# Altium schematic sheet TestPad_Schematic.SchDoc
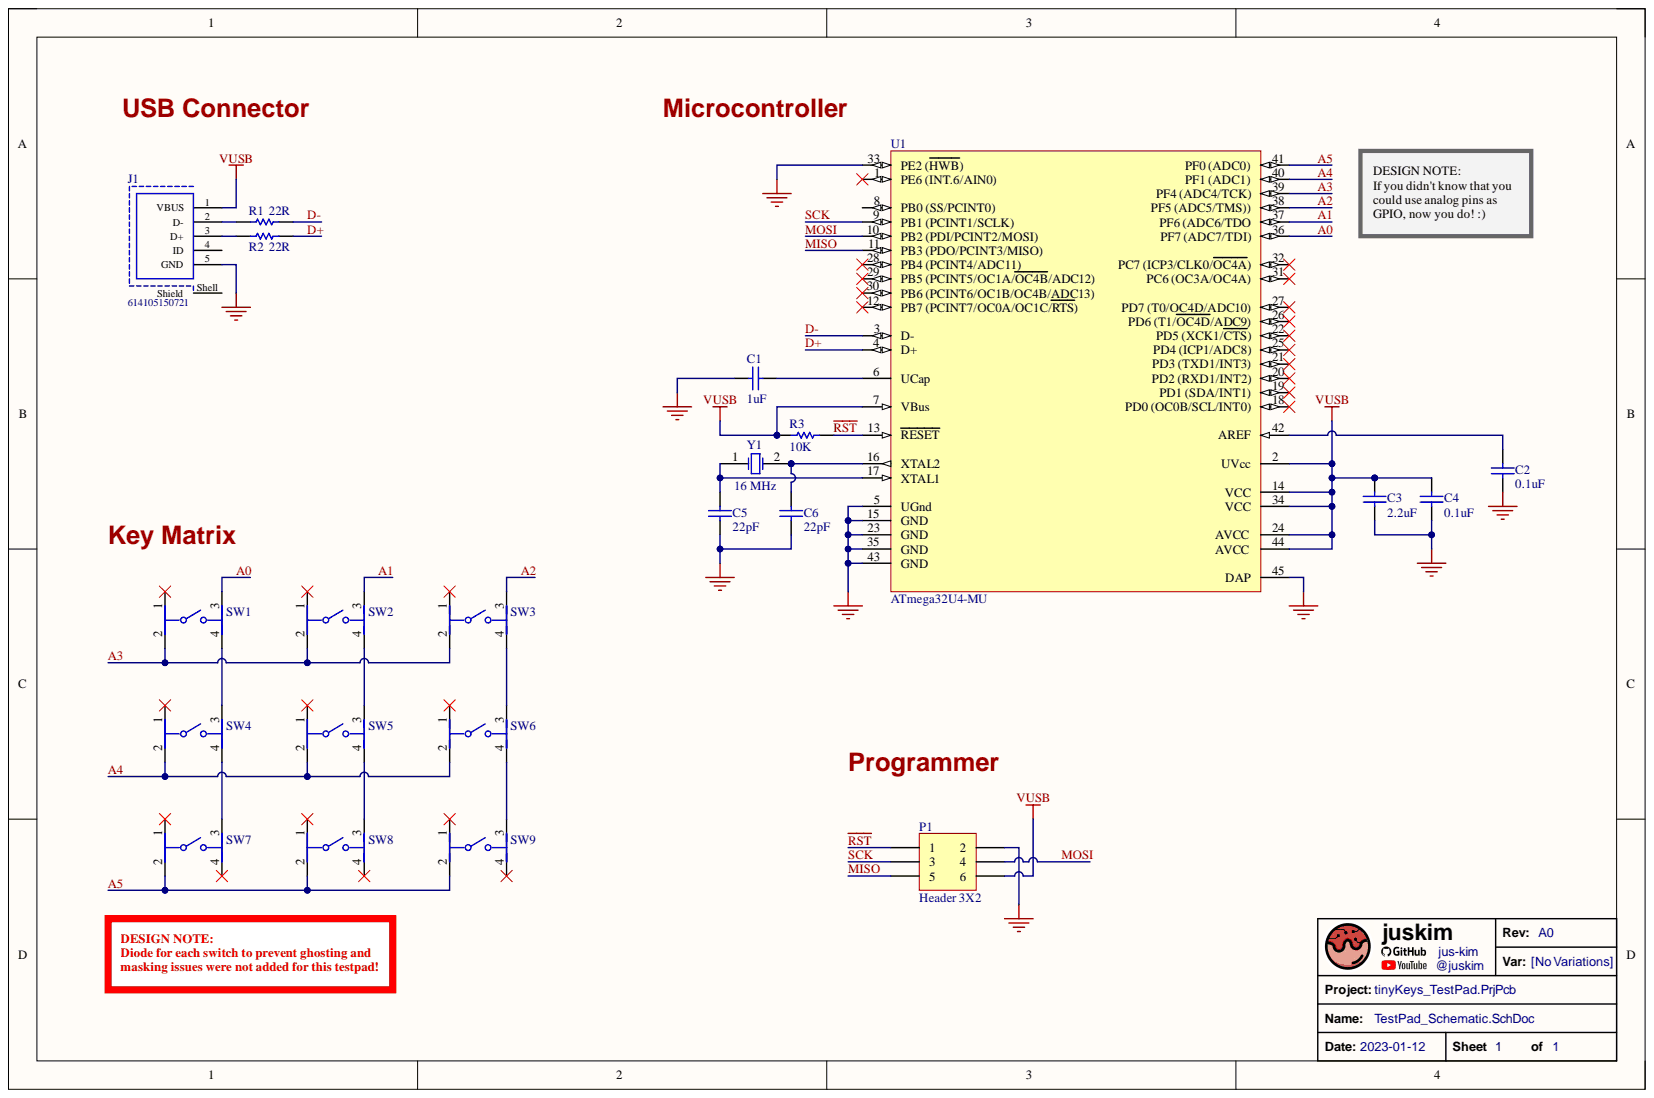
[diagram p0: TestPad_Schematic.SchDoc]

## Parts
C1  value 1uF  [RAD-0.3]
C2  value 0.1uF  [RAD-0.3]
C3  value 2.2uF  [RAD-0.3]
C4  value 0.1uF  [RAD-0.3]
C5  value 22pF  [RAD-0.3]
C6  value 22pF  [RAD-0.3]
J1  614105150721  [614105150721]
P1  Header 3X2  [HDR2X3]
R1  value 22R  [AXIAL-0.3]
R2  value 22R  [AXIAL-0.3]
R3  value 10K  [AXIAL-0.3]
SW1  1-1825910-0  [FP-1-1825910-0-MFG]  (value not drawn)
SW2  1-1825910-0  [FP-1-1825910-0-MFG]  (value not drawn)
SW3  1-1825910-0  [FP-1-1825910-0-MFG]  (value not drawn)
SW4  1-1825910-0  [FP-1-1825910-0-MFG]  (value not drawn)
SW5  1-1825910-0  [FP-1-1825910-0-MFG]  (value not drawn)
SW6  1-1825910-0  [FP-1-1825910-0-MFG]  (value not drawn)
SW7  1-1825910-0  [FP-1-1825910-0-MFG]  (value not drawn)
SW8  1-1825910-0  [FP-1-1825910-0-MFG]  (value not drawn)
SW9  1-1825910-0  [FP-1-1825910-0-MFG]  (value not drawn)
U1  ATmega32U4-MU  [44PW_M]
Y1  16 MHz  [R38]
  TitleBlock_juskim  (value not drawn)

## Nets
GND: C1.1 C2.2 C3.2 C4.2 C5.2 C6.2 J1.5(GND) P1.2 U1.5(UGnd) U1.15(GND) U1.23(GND) U1.33(PE2_(HWB)) U1.35(GND) U1.43(GND) U1.45(DAP)
VUSB: C3.1 C4.1 J1.1(VBUS) P1.6 R3.2 U1.2(UVcc) U1.7(VBus) U1.14(VCC) U1.24(AVCC) U1.34(VCC) U1.44(AVCC)
A0: SW1.3 U1.36(PF7_(ADC7/TDI))
A1: SW2.3 U1.37(PF6_(ADC6/TDO)
A2: SW3.3 U1.38(PF5_(ADC5/TMS)))
A3: SW1.2 SW2.2 SW3.2 U1.39(PF4_(ADC4/TCK))
A4: SW4.2 SW5.2 SW6.2 U1.40(PF1_(ADC1))
A5: SW7.2 SW8.2 SW9.2 U1.41(PF0_(ADC0))
D+: R2.1 U1.4(D+)
D-: R1.1 U1.3(D-)
MISO: P1.5 U1.11(PB3_(PDO/PCINT3/MISO))
MOSI: P1.4 U1.10(PB2_(PDI/PCINT2/MOSI))
~{RST}: P1.1 R3.1 U1.13(RESET)
SCK: P1.3 U1.9(PB1_(PCINT1/SCLK))
NetC1_2: C1.2 U1.6(UCap)
NetC2_1: C2.1 U1.42(AREF)
NetC5_1: C5.1 U1.17(XTAL1) Y1.1
NetC6_1: C6.1 U1.16(XTAL2) Y1.2
NetJ1_2: J1.2(D-) R1.2
NetJ1_3: J1.3(D+) R2.2
NetSW1_4: SW1.4 SW4.3
NetSW2_4: SW2.4 SW5.3
NetSW3_4: SW3.4 SW6.3
NetSW4_4: SW4.4 SW7.3
NetSW5_4: SW5.4 SW8.3
NetSW6_4: SW6.4 SW9.3

Not connected: J1 4(ID) Shell(Shield); SW1 1; SW2 1; SW3 1; SW4 1; SW5 1; SW6 1; SW7 1 4; SW8 1 4; SW9 1 4; U1 1(PE6_(INT.6/AIN0)) 8(PB0_(SS/PCINT0)) 12(PB7_(PCINT7/OC0A/OC1C/RTS)) 18(PD0_(OC0B/SCL/INT0)) 19(PD1_(SDA/INT1)) 20(PD2_(RXD1/INT2)) 21(PD3_(TXD1/INT3)) 22(PD5_(XCK1/CTS)) 25(PD4_(ICP1/ADC8)) 26(PD6_(T1/OC4D/ADC9)) 27(PD7_(T0/OC4D/ADC10)) 28(PB4_(PCINT4/ADC11)) 29(PB5_(PCINT5/OC1A/OC4B/ADC12)) 30(PB6_(PCINT6/OC1B/OC4B/ADC13)) 31(PC6_(OC3A/OC4A)) 32(PC7_(ICP3/CLK0/OC4A))

## Library data of the parts
C1-C6 = Cap: Capacitor; Component Kind: Standard; LatestRevisionDate: 17-Jul-2002; LatestRevisionNote: Re-released for DXP Platform.; Library Name: Miscellaneous Devices.IntLib; PackageDescription: Radial Cap, Thru-Hole; 2 Leads; 0.3 in Pin Spacing; PackageReference: RAD-0.3; Published: 8-Jun-2000; Signal Integrity: Cap; Simulation: CAP; Publisher: Altium Limited
J1 = CMP-1502-03250-1: Micro USB 2.0 Type B Receptacle WR-COM, Vertical, THT; Manufacturer: Wurth Elektronik; Contact Resistance: 30mOhm; Rated Current: 1.8A; Working Voltage: 30VAC; Component Kind: Standard; Connector Type: MicroUSB2.0TypeB; Datasheet URL: https://katalog.we-online.de/em/datasheet/614105150721.pdf; Gender: Receptacle; Library Name: Altium Content Vault; Manufacturer URL: http://www.we-online.de; Mounting Technology: THT; Operating Temperature: -40to85degC; Orientation: Vertical; Package Reference: 614105150721; Packaging: TapeandReel; Part Number: 614105150721; Publisher: WurthElektronik
P1 = Header 3X2: Header, 3-Pin, Dual row; Component Kind: Standard; LatestRevisionDate: 17-Jul-2002; LatestRevisionNote: Re-released for DXP Platform.; Library Name: Miscellaneous Connectors.IntLib; Signal Integrity: Connector; Publisher: Altium Limited
R1-R3 = Res1: Resistor; Component Kind: Standard; LatestRevisionDate: 17-Jul-2002; LatestRevisionNote: Re-released for DXP Platform.; Library Name: Miscellaneous Devices.IntLib; PackageDescription: Axial Device, Thru-Hole; 2 Leads; 0.3 in Pin Spacing; PackageReference: AXIAL-0.3; Published: 8-Jun-2000; Signal Integrity: Res; Simulation: RESISTOR; Publisher: Altium Limited
SW1-SW9 = CMP-03407-000018-1: SWITCH TACTILE SPST-NO 0.05A 24V; Actuator Color: Blue; Actuator Length: 3.4mm; Actuator Material: Nylon; Actuator Type: Standard; Contact Current Rating: 50mA; Contact Resistance: 100mR; Electrical Life: 100000Cycles; Illumination Type: Non-Illuminated; Operating Force: 1.56N; Switch Function: Off-Mom; Switch Travel: 0.25mm; Throw Configuration: SPST; Component Kind: Standard; Contact Material: Brass; Contact Plating: Silver; Depth: 5.99mm; Length: 5.99mm; Library Name: Altium Content Vault; Max Current Rating: 50mA; Max Operating Temperature: 85°C; Max Voltage Rating (DC): 24V; Mechanical Life: 100000Cycles; Min Operating Temperature: -35°C; Mount: Through Hole; Orientation: Straight; Package Quantity: 750; Packaging: Loose Piece; Radiation Hardening: No; RoHS Compliant: Yes; Series: FSMJ, Alcoswitch; Termination: PC Pins; Type: Switch; Voltage Rating (DC): 24V
U1 = CMP-0095-00166-2: 8-bit AVR Microcontroller, 2.7-5.5V, 16MHz, 32KB Flash, 1KB EEPROM, 2.5KB SRAM, USB Controller, 44-pin QFN, Industrial Grade (-40°C to 85°C), Ext Osc; Code_JEDEC: MO-220-VKKD-1; Component Kind: Standard; ComponentLink1Description: Manufacturer URL; ComponentLink1URL: http://www.atmel.com/; ComponentLink2Description: ATmega16U4/32U4 Datasheet; ComponentLink2URL: http://www.atmel.com/dyn/resources/prod_documents/doc7766.pdf; ComponentLink3Description: ATmega16U4 ATmega32U4 Summary; ComponentLink3URL: http://www.atmel.com/dyn/resources/prod_documents/7766S.pdf; DatasheetVersion: revF, Nov-2010; Library Name: Altium Content Vault; PackageDescription: 44-Pin Quad Flat No-Lead Package, 7 x 7 mm Body, 0.5 mm Pitch (QFN); PackageReference: 44PW; PackageVersion: revG, Sep-2009; RevisionNote: DatasheetVersion, PackageVersion & PCB updated. URLs added.
Y1 = XTAL: Component Kind: Standard; Description: 16 MHz; LatestRevisionDate: 17-Jul-2002; LatestRevisionNote: Re-released for DXP Platform.; Library Name: Miscellaneous Devices.IntLib; PackageDescription: Crystal, Thru-Hole; 2 Leads; Body 3.1 x 8.2 mm (Dia.xH); PackageReference: R38; Published: 8-Jun-2000; Simulation: XTAL; Publisher: Altium Limited
 = TitleBlock_juskim: Component Kind: Standard; Library Name: juskim_SchLib.SchLib
